# Altium schematic sheet 02radio.SchDoc
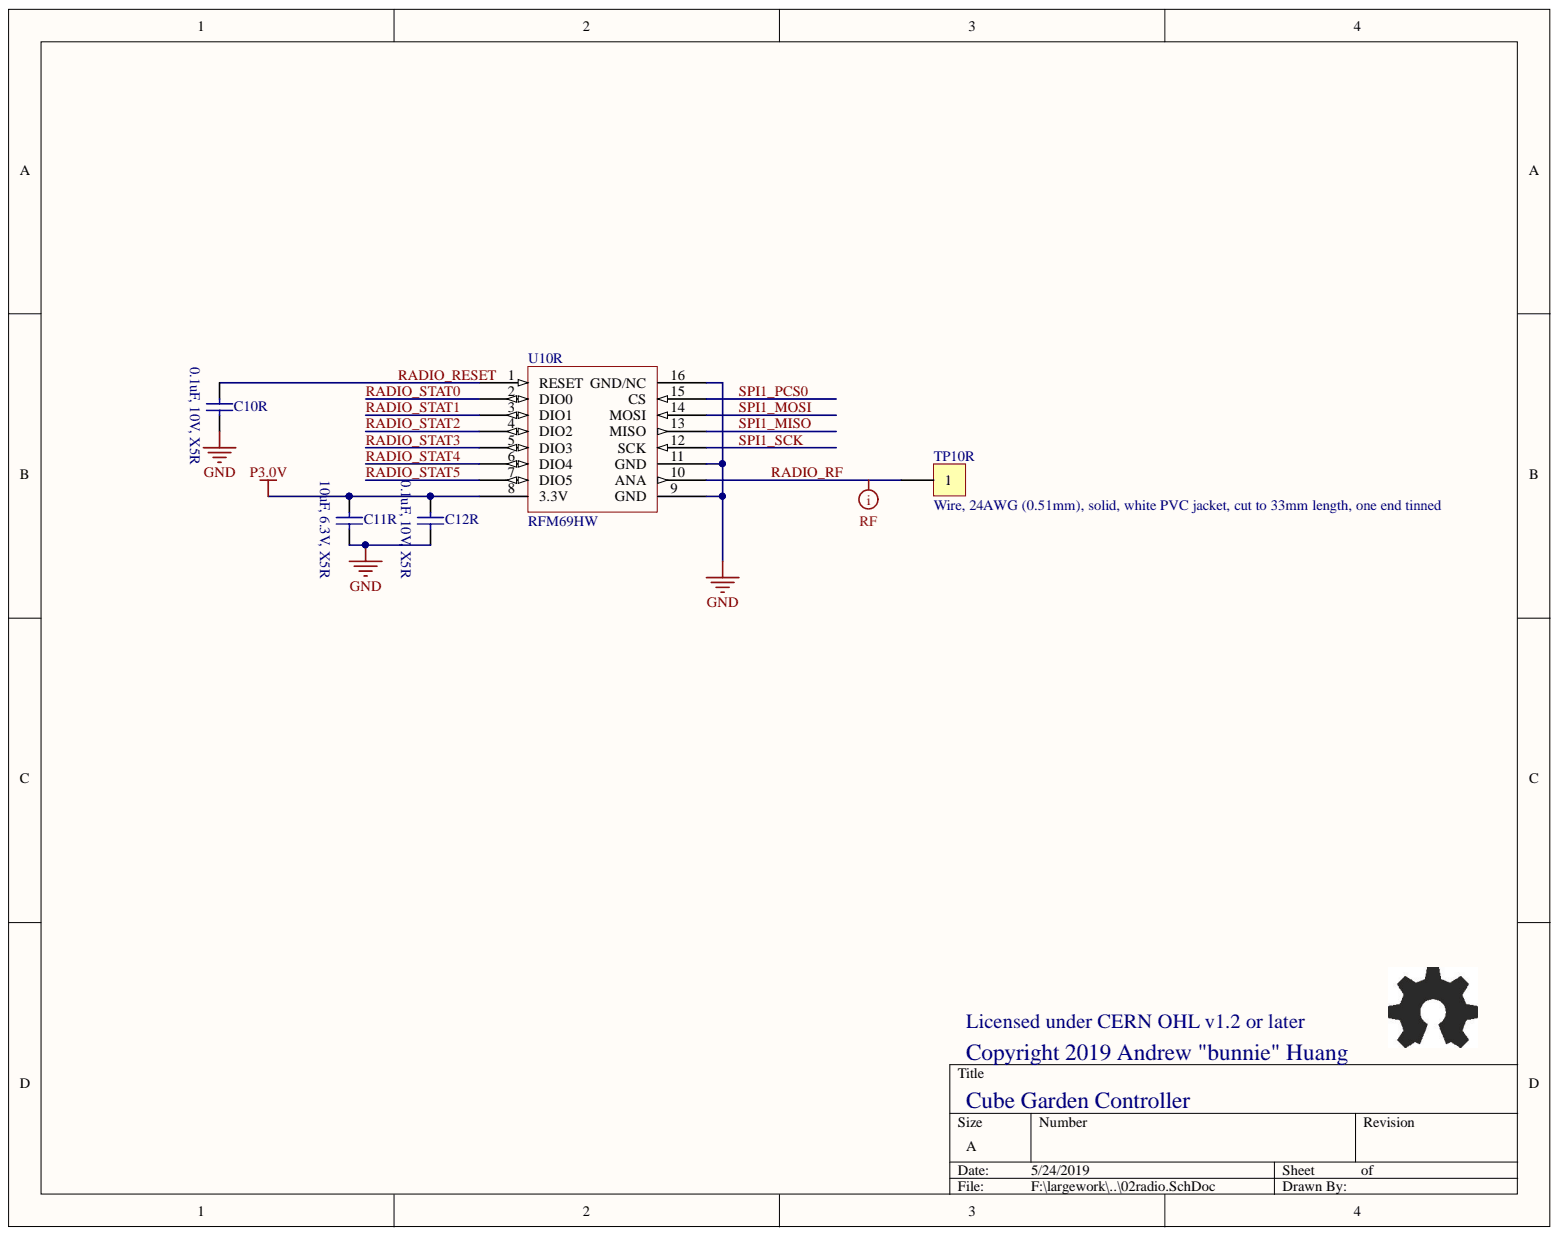
[diagram p0: 02radio.SchDoc]

## Parts
C10R  0.1uF, 10V, X5R  [B_CAP0402]
C11R  10uF, 6.3V, X5R  [B_CAP0603]
C12R  0.1uF, 10V, X5R  [B_CAP0402]
TP10R  Wire, 24AWG (0.51mm), solid, white PVC jacket, cut to 33mm length, one end tinned  [55-MIL-HOLE]
U10R  RFM69HW  [HOPERF_S2]

## Nets
GND: C10R.2 C11R.2 C12R.2 U10R.9(GND) U10R.11(GND) U10R.16(GND/NC)
P3.0V: C11R.1 C12R.1 U10R.8(3.3V)
RADIO_RESET: C10R.1 U10R.1(RESET)
RADIO_RF: TP10R.1 U10R.10(ANA)
RADIO_STAT0: U10R.2(DIO0)
RADIO_STAT1: U10R.3(DIO1)
RADIO_STAT2: U10R.4(DIO2)
RADIO_STAT3: U10R.5(DIO3)
RADIO_STAT4: U10R.6(DIO4)
RADIO_STAT5: U10R.7(DIO5)
SPI1_MISO: U10R.13(MISO)
SPI1_MOSI: U10R.14(MOSI)
SPI1_PCS0: U10R.15(CS)
SPI1_SCK: U10R.12(SCK)

## Library data of the parts
C10R C12R = Cap Semi: Capacitor (Semiconductor SIM Model); MPN: CL05A104KP5NNNC; MYPN: C-104-10-X5R-0402; VENDOR: Samsung; Component Kind: Standard; Library Name: Miscellaneous Devices.IntLib; Signal Integrity: Cap Semi; Simulation: CAP
C11R = Cap Semi: Capacitor (Semiconductor SIM Model); MPN: CL10A106MQ8NNNC; MYPN: C-106-6.3-X5R-0603; VENDOR: Samsung; Component Kind: Standard; Library Name: Miscellaneous Devices.IntLib; Signal Integrity: Cap Semi; Simulation: CAP
TP10R = CON1: Connector; MPN: ANY; MYPN: WIRE-ANTENNA; Component Kind: Standard; VENDOR: ANY
U10R = RFM69HW: ISM Transceiver Module; MPN: RFM69HW-915S2; MYPN: U-RFM69HW-915S2; VENDOR: Hope RF; Component Kind: Standard; Library Name: commonsch-new.SchLib
